# Altium schematic sheet SIM808_Power.SchDoc
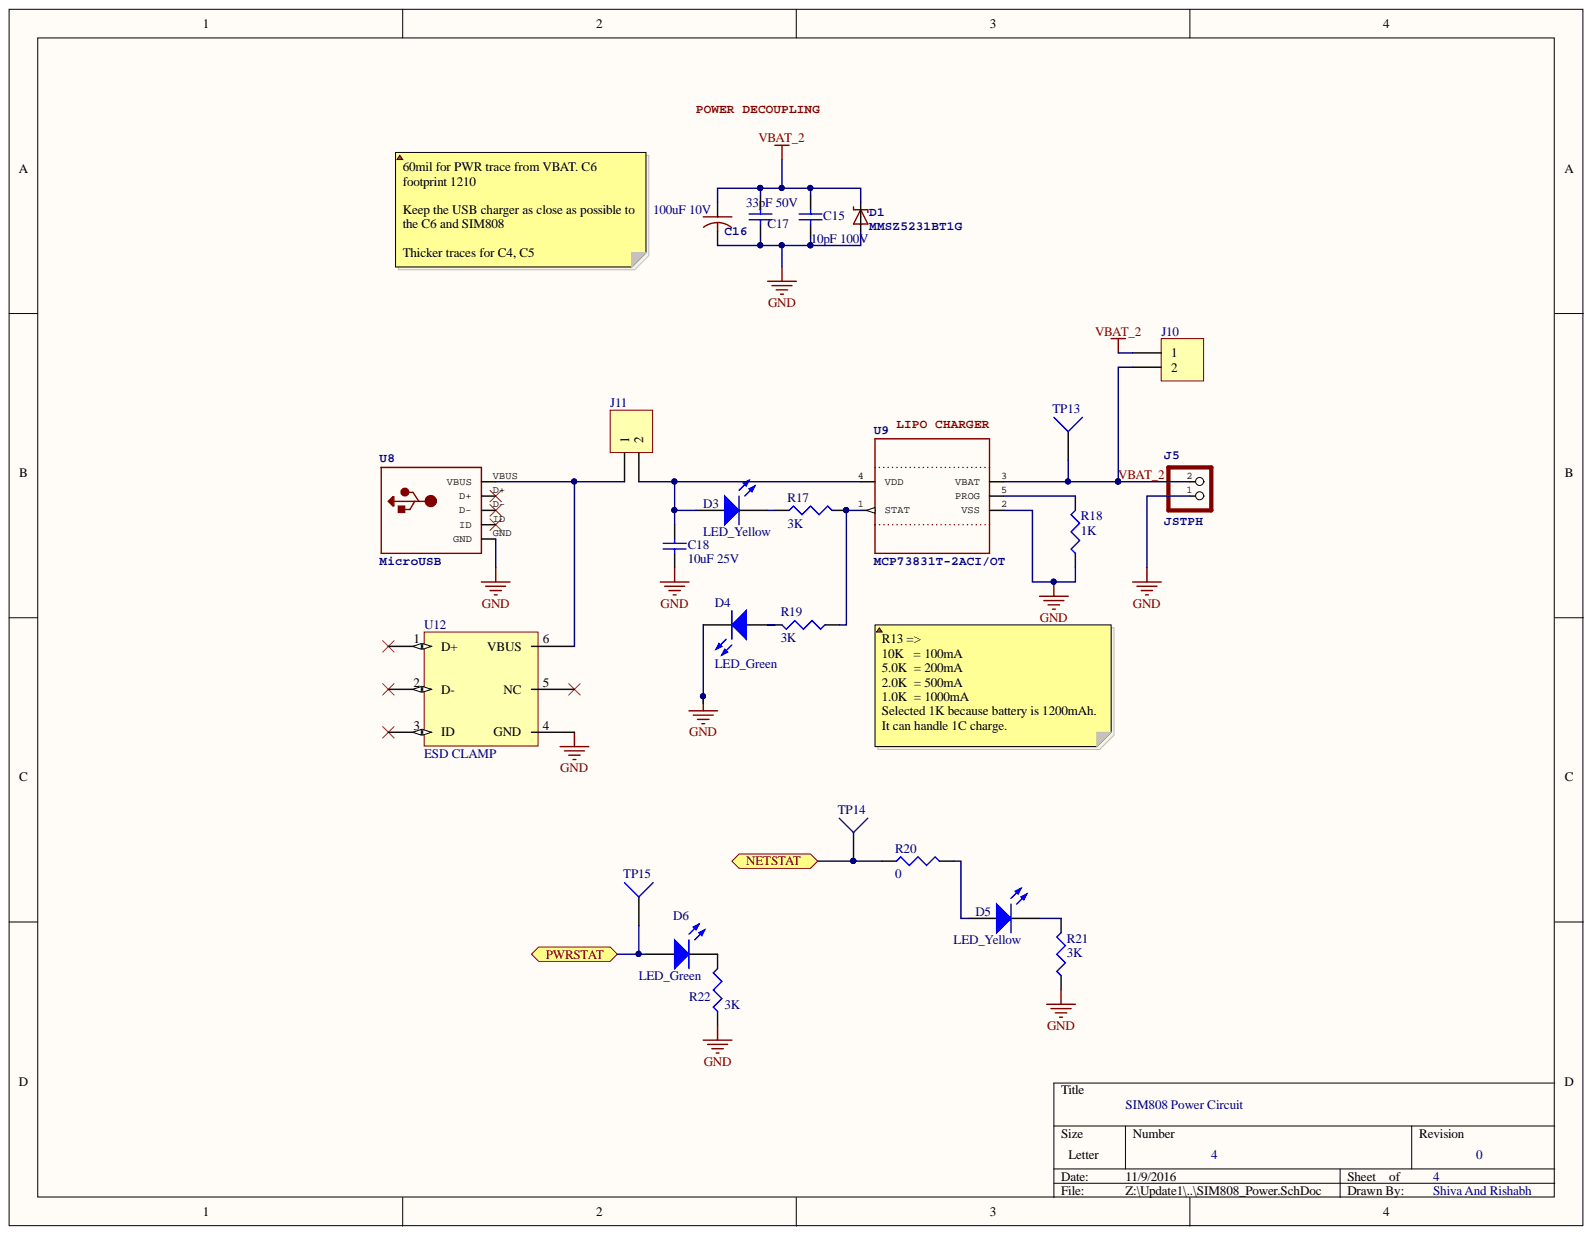
[diagram p0: SIM808_Power.SchDoc]

## Parts
C15  value 10pF 100V  [Cap_1608[0603]]  (hidden comment: Cap10pF)
C16  100uF  value 100uF 10V  [Cap_1210]
C17  value 33pF 50V  [Cap_1608[0603]]  (hidden comment: Cap33pF)
C18  value 10uF 25V  [Cap_1608[0603]]  (hidden comment: Cap10uF)
D1  MMSZ5231BT1G  [ZenerDiode_SOD-123]
D3  LED_Yellow  [LED_0603]
D4  LED_Green  [LED_0603]
D5  LED_Yellow  [LED_0603]
D6  LED_Green  [LED_0603]
J5  JSTPH  [JSTPH2]
J10  Header 2  [JMPR_HDR1X2]  (value not drawn)
J11  Header 2  [JMPR_HDR1X2]  (value not drawn)
R17  value 3K  [Res_0603]  (hidden comment: Res3K)
R18  value 1K  [Res_0603]  (hidden comment: Res1K)
R19  value 3K  [Res_0603]  (hidden comment: Res3K)
R20  value 0  [Res_0603]  (hidden comment: Res0)
R21  value 3K  [Res_0603]  (hidden comment: Res3K)
R22  value 3K  [Res_0603]  (hidden comment: Res3K)
TP13  Test Point  [TESTPOINT_1]  (value not drawn)
TP14  Test Point  [TESTPOINT_1]  (value not drawn)
TP15  Test Point  [TESTPOINT_1]  (value not drawn)
U8  MicroUSB  [MicroUSB_Conn]
U9  MCP73831T-2ACI/OT  [MCP7383_SOT23-5]
U12  ESD CLAMP  [TPD4S012_SON6]

## Ports
NETSTAT, PWRSTAT

## Nets
GND: C15.1 C16.2 C17.1 C18.1 D1.A D4.2 J5.1 R18.1 R21.1 R22.1 U8.GND U9.2(VSS) U12.4(GND)
NETSTAT: R20.1 TP14.1 ; port NETSTAT
PWRSTAT: D6.1 TP15.1 ; port PWRSTAT
VBAT_2: C15.2 C16.1 C17.2 D1.C J5.2 J10.1 J10.2 TP13.1 U9.3(VBAT)
NetC18_2: C18.2 D3.1 J11.2 U9.4(VDD)
NetD3_2: D3.2 R17.1
NetD4_1: D4.1 R19.2
NetD5_1: D5.1 R20.2
NetD5_2: D5.2 R21.2
NetD6_2: D6.2 R22.2
NetJ11_1: J11.1 U8.VBUS U12.6(VBUS)
NetR17_2: R17.2 R19.1 U9.1(STAT)
NetR18_2: R18.2 U9.5(PROG)

Not connected: U8 D+ D- ID; U12 1(D+) 2(D-) 3(ID) 5(NC)

## Library data of the parts
C15 = Cap10pF: Capacitor (Semiconductor SIM Model); Manufacturer: Murata Electronics; ManufacturerPartNo: GRM1885C2A100JA01D; Value: 10pF 100V; Component Kind: Standard; ComponentLink1Description: Datasheet; ComponentLink1URL: http://search.murata.co.jp/Ceramy/image/img/A01X/G101/ENG/GRM1885C2A100JA01-01.pdf; Library Name: LR_Integrated_Library.IntLib; Supplier: Digikey; SupplierLink1URL: http://www.digikey.com/product-detail/en/murata-electronics-north-america/GRM1885C2A100JA01D/490-6388-1-ND/3845585; SupplierPartNo: 490-6388-1-ND
C16 = CapPol100uF: CAPACITOR, American symbol; Manufacturer: AVX Corporation; ManufacturerPartNo: F951A107KTAAQ2; Component Kind: Standard; ComponentLink1Description: Datasheet; ComponentLink1URL: http://datasheets.avx.com/f95.pdf; Library Name: LR_Integrated_Library.IntLib; Supplier: Digikey; SupplierLink1URL: http://www.digikey.com/product-search/en?keywords=478-8420-1-ND; SupplierPartNo: 478-8420-1-ND
C17 = Cap33pF: Capacitor (Semiconductor SIM Model); Manufacturer: Murata Electronics; ManufacturerPartNo: GRM1885C1H330JA01D; Component Kind: Standard; ComponentLink1Description: Datasheet; ComponentLink1URL: http://search.murata.co.jp/Ceramy/image/img/A01X/G101/ENG/GRM1885C1H330JA01-01.pdf; Library Name: LR_Integrated_Library.IntLib; Supplier: Digikey; SupplierLink1URL: http://www.digikey.com/product-detail/en/murata-electronics-north-america/GRM1885C1H330JA01D/490-1415-1-ND/587620; SupplierPartNo: 490-1415-1-ND
C18 = Cap10uF: Capacitor (Semiconductor SIM Model); Manufacturer: Murata Electronics North America; ManufacturerPartNo: GRM188R61E106MA73D; Component Kind: Standard; ComponentLink1Description: Datasheet; ComponentLink1URL: http://search.murata.co.jp/Ceramy/image/img/A01X/G101/ENG/GRM188R61E106MA73-01.pdf; Library Name: LR_Integrated_Library.IntLib; Supplier: Digikey; SupplierLink1URL: http://www.digikey.com/product-detail/en/murata-electronics-north-america/GRM319R71H104KA01D/490-1775-1-ND/587528; SupplierPartNo: 490-7202-1-ND
D1 = ZenerDiode: Zener Diode   SMA: 30V 1W Zener Diode, 1.2Vf - Digikey: SMAZ30-FDICT-ND; Manufacturer: Diodes Incorporated; ManufacturerPartNo: SMAZ30-13-F; Value: 30V 1W; Component Kind: Standard; ComponentLink1Description: Datasheet; ComponentLink1URL: http://www.diodes.com/_files/datasheets/ds18015.pdf; Library Name: LR_Integrated_Library.IntLib; Quantity: 1; Supplier: Digikey; SupplierLink1URL: http://www.digikey.com/product-search/en?keywords=SMAZ30-FDICT-ND; SupplierPartNo: SMAZ30-FDICT-ND
D3 D5 = LED_Yellow: Manufacturer: OSRAM; ManufacturerPartNo: LY L29K-H1K2-26-Z; Component Kind: Standard; ComponentLink1Description: Datasheet; ComponentLink1URL: http://www.osram-os.com/Graphics/XPic1/00077106_0.pdf; Description: LED_Yellow; Library Name: LR_Integrated_Library.IntLib; PackageDescription: SM LED; 2 Flat Leads; Supplier: Digikey; SupplierLink1URL: http://www.digikey.com/product-search/en?keywords=475-3118-1-ND; SupplierPartNo: 475-1196-1-ND
D4 D6 = LED_Green: Manufacturer: OSRAM; ManufacturerPartNo: LG L29K-F2J1-24-Z; Component Kind: Standard; ComponentLink1Description: Datasheet; ComponentLink1URL: http://www.osram-os.com/Graphics/XPic0/00167682_0.pdf; Description: LED_Green; Library Name: LR_Integrated_Library.IntLib; PackageDescription: SM LED; 2 Flat Leads; Quantity: 2; Supplier: Digikey; SupplierLink1URL: http://www.digikey.com/product-search/en?keywords=475-3118-1-ND; SupplierPartNo: 475-3118-1-ND
J5 = JST_2PIN: JST 2-Pin Right-Angle Connector  PH-Series - 4UConnector: 17311  SH-Series - 4UConnector: 07278; ManufacturerPartNo: 17311; Component Kind: Standard; ComponentLink1Description: Drawing/Datasheet; ComponentLink1URL: https://cdn-shop.adafruit.com/datasheets/17311.pdf; Library Name: LR_Integrated_Library.IntLib; Manufacturer: 4UConnector; Supplier: Adafruit; SupplierLink1URL: https://www.adafruit.com/product/1769; SupplierPartNo: 1769
J10 J11 = Header 2: Header, 2-Pin; Manufacturer: Harwin Inc.; ManufacturerPartNo: M20-9990246; Component Kind: Standard; ComponentLink1Description: Drawing/Datasheet; ComponentLink1URL: https://cdn.harwin.com/pdfs/60page146.pdf; Library Name: LR_Integrated_Library.IntLib; Supplier: Digikey; SupplierLink1URL: http://www.digikey.com/product-search/en?keywords=952-2262-ND; SupplierPartNo: 952-2262-ND
R17 R19 R21 R22 = Res3K: Resistor; Manufacturer: Yageo; ManufacturerPartNo: AC0603FR-073KL; Component Kind: Standard; ComponentLink1Description: Datasheet; ComponentLink1URL: http://www.yageo.com/documents/recent/PYu-AC_51_RoHS_L%205.pdf; Library Name: LR_Integrated_Library.IntLib; Supplier: Digikey; SupplierLink1URL: http://www.digikey.com/product-detail/en/yageo/AC0603FR-073KL/YAG3607CT-ND/6006456; SupplierPartNo: YAG3607CT-ND
R18 = Res1K: Resistor; Manufacturer: Yageo; ManufacturerPartNo: AC0603FR-071KL; Component Kind: Standard; ComponentLink1Description: Datasheet; ComponentLink1URL: http://www.yageo.com/NewPortal/yageodocoutput?fileName=/pdf/R-Chip/PYu-AC_51_RoHS_L_5.pdf; Library Name: LR_Integrated_Library.IntLib; Supplier: Digikey; SupplierLink1URL: http://www.digikey.com/product-search/en?keywords=311-1KLDCT-ND; SupplierPartNo: 311-1KLDCT-ND
R20 = Res0: Resistor; Manufacturer: Yageo; ManufacturerPartNo: AC0603JR-070RL; Component Kind: Standard; ComponentLink1Description: Datasheet; ComponentLink1URL: http://www.yageo.com/NewPortal/yageodocoutput?fileName=/pdf/R-Chip/PYu-AC_51_RoHS_L_5.pdf; Library Name: LR_Integrated_Library.IntLib; Supplier: Digikey; SupplierLink1URL: http://www.digikey.com/product-detail/en/yageo/AC0603JR-070RL/311-0.0LECT-ND/2828149; SupplierPartNo: 311-0.0LECT-ND
U8 = MicroUSB: USB ConnectorsUSBMINIB - Surface Mount Female Mini-B USB Connector    4UConnector: 06564; Manufacturer: Hirose Electric Co Ltd; ManufacturerPartNo: 10118193-0001LF; Component Kind: Standard; ComponentLink1Description: Datasheet; ComponentLink1URL: https://www.hirose.com/product/en/download_file/key_name/ZX/category/Catalog/doc_file_id/31704/?file_category_id=4&item_id=13&is_series=1; Library Name: LR_Integrated_Library.IntLib; Supplier: Digikey; SupplierLink1URL: http://www.digikey.com/product-search/en?keywords=H12193CT-ND; SupplierPartNo: ZX62WD1-B-5PC
U9 = MCP73831/2: MCP73831/2 LIPO Charger    VDD: 3.75 - 6V  Temp -40 - 85°C  Programmable Charge Rate: 15-500mA       NOTE: STAT is a tri-state logic output on the MCP73831 and an open-drain output on the MCP73832.   SOT23-5 with 4.2V output and tri-state logic on STAT = MCP73831T-2ACI/OT; Manufacturer: Microchip Technology; Component Kind: Standard; ComponentLink1Description: Datasheet; ComponentLink1URL: http://ww1.microchip.com/downloads/en/DeviceDoc/20001984g.pdf; Library Name: LR_Integrated_Library.IntLib; ManufacturerPartNo: MCP73831T-2ACI/OT; Quantity: 1; Supplier: Digikey; SupplierLink1URL: http://www.digikey.com/product-detail/en/microchip-technology/MCP73831T-2ACI-OT/MCP73831T-2ACI-OTTR-ND/964301; SupplierPartNo: MCP73831T-2ACI/OTTR-ND
U12 = TPD4S012: IC 4CH ESD SOLUTION W/CLAMP; Manufacturer: Texas Instruments; ManufacturerPartNo: TPD4S012DRYR; Component Kind: Standard; ComponentLink1Description: Datasheet; ComponentLink1URL: http://www.ti.com/lit/ds/symlink/tpd4s012.pdf; Library Name: LR_Integrated_Library.IntLib; Supplier: Digikey; SupplierLink1URL: http://www.digikey.com/product-search/en/circuit-protection/tvs-mixed-technology/656225?k=TPD4S012&k=&pkeyword=TPD4S012&pv7=2&mnonly=0&newproducts=0&ColumnSort=0&page=1&quantity=0&ptm=0&fid=0&pageSize=25; SupplierPartNo: 296-24244-1-ND
TP13-TP15 = Test Point: Component Kind: Standard; Description: Test Point; LatestRevisionDate: 17-Jul-2002; LatestRevisionNote: Re-released for DXP Platform.; Library Name: LR_Integrated_Library.IntLib; Publisher: Altium Limited
